# Altium schematic sheet [03] - MAIN SCHEMATIC.SchDoc
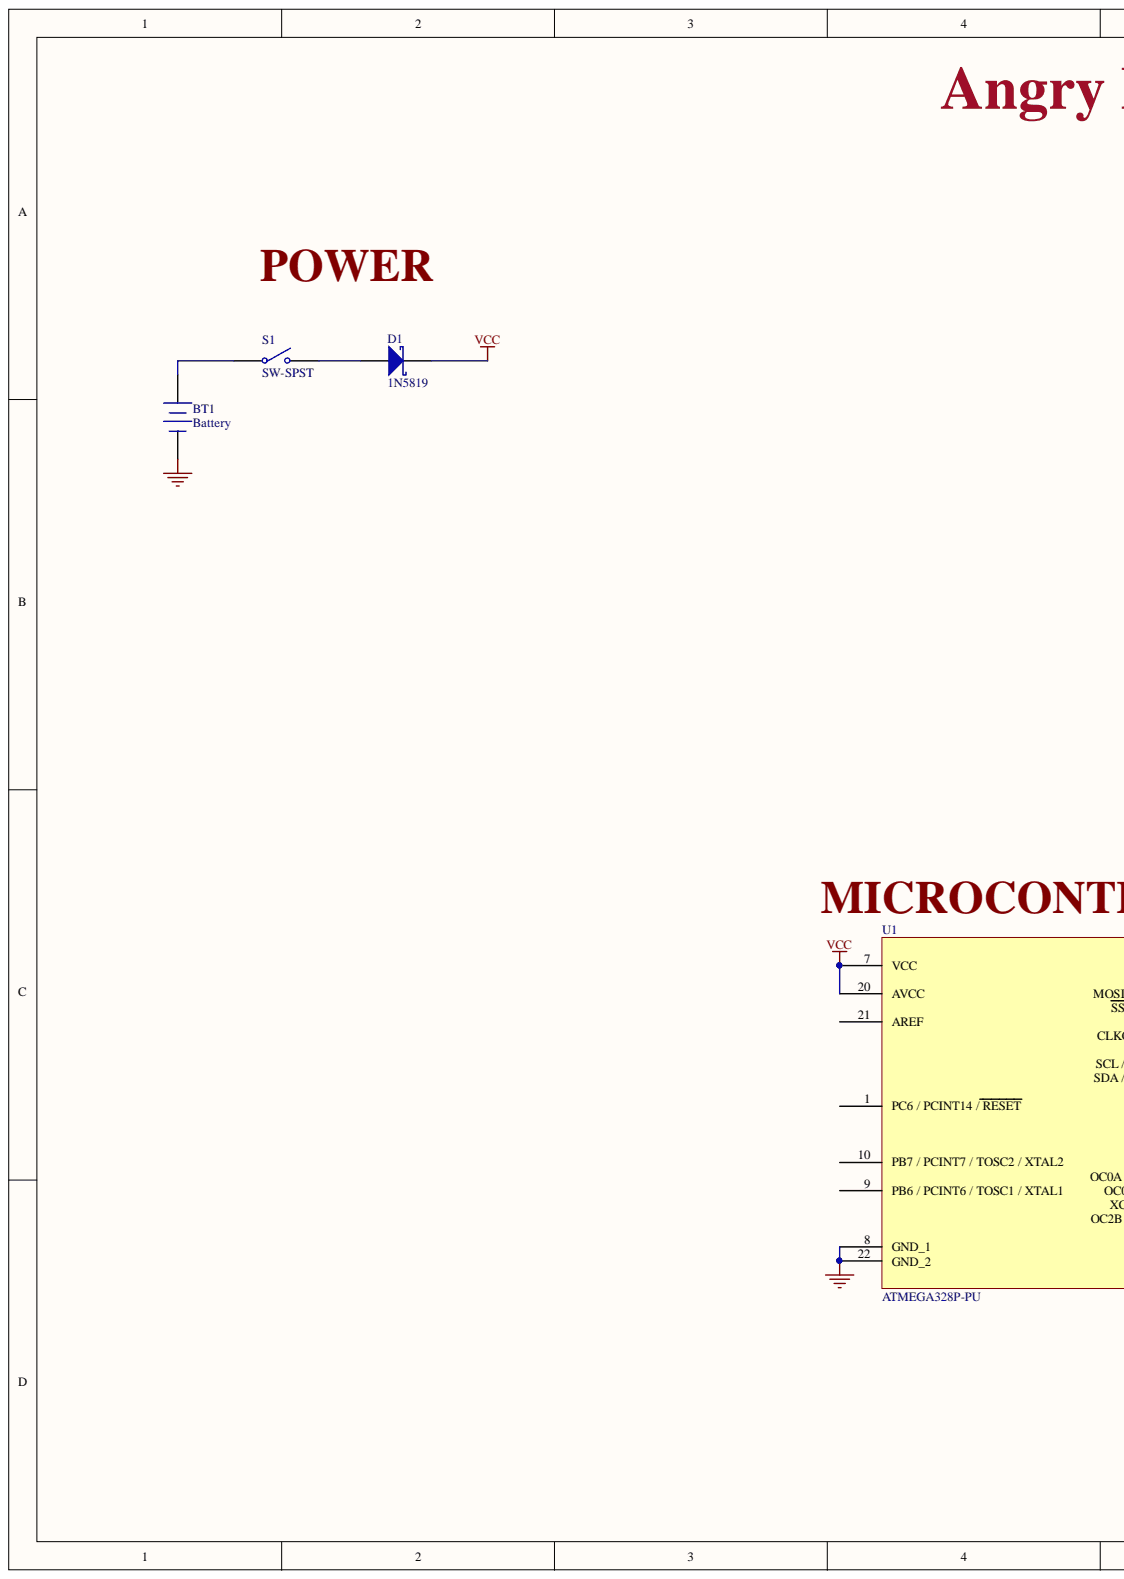
[diagram p0: [03] - MAIN SCHEMATIC.SchDoc, left]
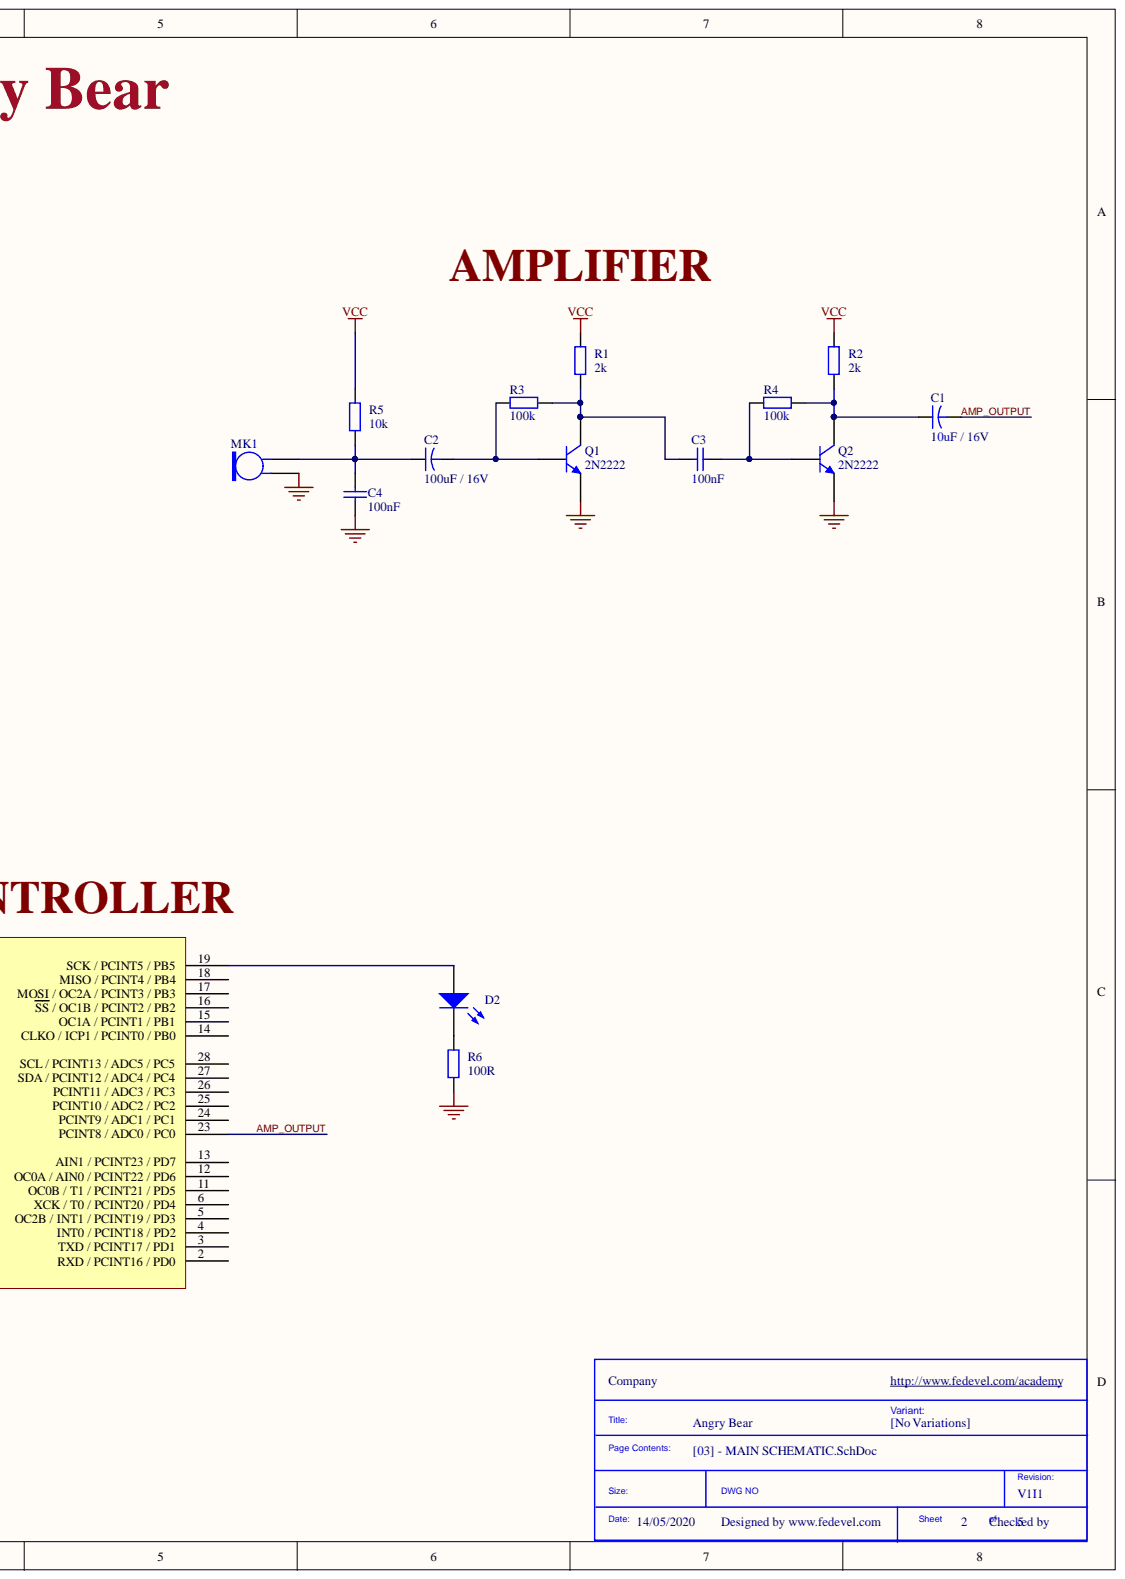
[diagram p1: [03] - MAIN SCHEMATIC.SchDoc, right]

## Parts
BT1  Battery  [BAT-2]
C1  10uF / 16V  [CAPR5-4X5]
C2  100uF / 16V  [CAPR5-4X5]
C3  100nF  [RAD-0.3]
C4  100nF  [RAD-0.3]
D1  1N5819  [DO-204AL]
D2  LED0  [LED-0]  (value not drawn)
MK1  Mic2  [PIN2]  (value not drawn)
Q1  2N2222  [TO-226-AA]
Q2  2N2222  [TO-226-AA]
R1  2k  [AXIAL-0.4]
R2  2k  [AXIAL-0.4]
R3  100k  [AXIAL-0.4]
R4  100k  [AXIAL-0.4]
R5  10k  [AXIAL-0.4]
R6  100R  [AXIAL-0.4]
S1  SW-SPST  [SPST-2]
U1  ATMEGA328P-PU  [28_DIP_7.62mm]

## Nets
GND: BT1.2 C4.1 MK1.1 Q1.3 Q2.3 R6.1 U1.8(GND_1) U1.22(GND_2)
VCC: D1.2 R1.2 R2.2 R5.2 U1.7(VCC) U1.20(AVCC)
AMP_OUTPUT: C1.2 U1.23(PCINT8_/_ADC0_/_PC0)
NetBT1_1: BT1.1 S1.1
NetC1_1: C1.1 Q2.1 R2.1 R4.2
NetC2_1: C2.1 C4.2 MK1.2 R5.1
NetC2_2: C2.2 Q1.2 R3.1
NetC3_1: C3.1 Q1.1 R1.1 R3.2
NetC3_2: C3.2 Q2.2 R4.1
NetD1_1: D1.1 S1.2
NetD2_1: D2.1 U1.19(SCK_/_PCINT5_/_PB5)
NetD2_2: D2.2 R6.2

Not connected: U1 1(PC6_/_PCINT14_/_RESET) 2(RXD_/_PCINT16_/_PD0) 3(TXD_/_PCINT17_/_PD1) 4(INT0_/_PCINT18_/_PD2) 5(OC2B_/_INT1_/_PCINT19_/_PD3) 6(XCK_/_T0_/_PCINT20_/_PD4) 9(PB6_/_PCINT6_/_TOSC1_/_XTAL1) 10(PB7_/_PCINT7_/_TOSC2_/_XTAL2) 11(OC0B_/_T1_/_PCINT21_/_PD5) 12(OC0A_/_AIN0_/_PCINT22_/_PD6) 13(AIN1_/_PCINT23_/_PD7) 14(CLKO_/_ICP1_/_PCINT0_/_PB0) 15(OC1A_/_PCINT1_/_PB1) 16(SS_/_OC1B_/_PCINT2_/_PB2) 17(MOSI_/_OC2A_/_PCINT3_/_PB3) 18(MISO_/_PCINT4_/_PB4) 21(AREF) 24(PCINT9_/_ADC1_/_PC1) 25(PCINT10_/_ADC2_/_PC2) 26(PCINT11_/_ADC3_/_PC3) 27(SDA_/_PCINT12_/_ADC4_/_PC4) 28(SCL_/_PCINT13_/_ADC5_/_PC5)

## Library data of the parts
BT1 = Battery: Multicell Battery; Component Kind: Standard; LatestRevisionDate: 17-Jul-2002; LatestRevisionNote: Re-released for DXP Platform.; Library Name: Miscellaneous Devices.IntLib; PackageDescription: Battery; 2 Leads; PackageReference: BAT-2; Published: 24-Mar-1999; Publisher: Altium Limited
C1 C2 = Cap2: Capacitor; Value: 100pF; Component Kind: Standard; Library Name: Miscellaneous Devices.IntLib; PackageDescription: Capacitor; 2 Leads; PackageReference: CAPR5-4X5; Published: 23-Sep-2002; Signal Integrity: Cap; Simulation: CAP; Publisher: Altium Limited
C3 C4 = Cap: Capacitor; Value: 100pF; Component Kind: Standard; LatestRevisionDate: 17-Jul-2002; LatestRevisionNote: Re-released for DXP Platform.; Library Name: Miscellaneous Devices.IntLib; PackageDescription: Radial Cap, Thru-Hole; 2 Leads; 0.3 in Pin Spacing; PackageReference: RAD-0.3; Published: 8-Jun-2000; Signal Integrity: Cap; Simulation: CAP; Publisher: Altium Limited
D1 = Diode 11DQ03: Schottky Rectifier; Code_JEDEC: DO-204-AL; Component Kind: Standard; ComponentLink1Description: Manufacturer Link; ComponentLink1URL: http://www.irf.com/; ComponentLink2Description: Datasheet; ComponentLink2URL: http://www.irf.com/product-info/datasheets/data/11dq03.pdf; DatasheetVersion: Dec-1997; LatestRevisionDate: 17-Jul-2002; LatestRevisionNote: Re-released for DXP Platform.; Library Name: Miscellaneous Devices.IntLib; PackageDescription: Diode, Axial; Body 5.2 x 2.7 mm (LxDia. max), Lead Dia. 0.86 mm (max); PackageReference: DO-204AL; Published: 8-Jun-2000; Simulation: 11DQ03; Publisher: Altium Limited
D2 = LED0: Typical INFRARED GaAs LED; Component Kind: Standard; LatestRevisionDate: 08-Jul-2005; LatestRevisionNote: Mech Layer 1 removed.; Library Name: Miscellaneous Devices.IntLib; PackageDescription: LED; 2 Leads; PackageReference: LED-0; Published: 8-Jun-2000; Simulation: LED0; Publisher: Altium Limited
MK1 = Mic2: Microphone; Component Kind: Standard; LatestRevisionDate: 17-Jul-2002; LatestRevisionNote: Re-released for DXP Platform.; Library Name: Miscellaneous Devices.IntLib; PackageReference: PIN2; Published: 24-Mar-1999; Publisher: Altium Limited
Q1 Q2 = NPN: NPN Bipolar Transistor; Manufacturer: ON Semiconductor; Manufacturer Part Number: P2N2222AG; Collector Emitter Voltage V(br)ceo: 40V; DC Collector Current: 600mA; DC Current Gain hFE: 300hFE; No. of Pins: 3Pins; Power Dissipation Pd: 625mW; SVHC: No SVHC (17-Dec-2015); Transistor Case Style: TO-92; Transition Frequency ft: 300MHz; Automotive Qualification Standard: -; Code_JEDEC: TO-226-AA; Component Kind: Standard; ComponentLink1Description: https://www.onsemi.com/pub/Collateral/P2N2222A-D.PDF?src-supplier=Digi-Key; ComponentLink1URL: https://www.onsemi.com/pub/Collateral/P2N2222A-D.PDF?src-supplier=Digi-Key; ComponentLink2Description: http://www.mouser.com/ds/2/308/on%20semiconductor_p2n2222a-d-548821.pdf?src-supplier=Mouser; ComponentLink2URL: http://www.mouser.com/ds/2/308/on%20semiconductor_p2n2222a-d-548821.pdf?src-supplier=Mouser; ComponentLink3Description: http://www.onsemi.com/pub/Collateral/P2N2222A-D.PDF?src-supplier=Avnet; ComponentLink3URL: http://www.onsemi.com/pub/Collateral/P2N2222A-D.PDF?src-supplier=Avnet; ComponentLink4Description: http://www.onsemi.com/pub/Collateral/P2N2222A-D.PDF?src-supplier=Avnet+Europe; ComponentLink4URL: http://www.onsemi.com/pub/Collateral/P2N2222A-D.PDF?src-supplier=Avnet+Europe; ComponentLink5Description: http://www.onsemi.com/pub_link/Collateral/P2N2222A-D.PDF?src-supplier=Future+Electronics; ComponentLink5URL: http://www.onsemi.com/pub_link/Collateral/P2N2222A-D.PDF?src-supplier=Future+Electronics; ComponentLink6Description: https://www.verical.com/server-webapp/html/getDatasheet?partID=69103&src-supplier=Verical; ComponentLink6URL: https://www.verical.com/server-webapp/html/getDatasheet?partID=69103&src-supplier=Verical; LatestRevisionDate: 17-Jul-2002; LatestRevisionNote: Re-released for DXP Platform.; Library Name: Miscellaneous Devices.IntLib; MSL: MSL 1 - Unlimited; Operating Temperature Max: 150°C; PackageDescription: TO, Flat Index; 3 In-Line, Axial Leads; Body Dia. 4.8mm; Leads 0.55 x 0.51 mm (max); PackageReference: TO-226-AA; Product Range: -; Published: 8-Jun-2000; Signal Integrity: NPN; Simulation: NPN; Stock 1: 0; Supplier 1: Newark; Supplier Part Number 1: 42K2503; Transistor Polarity: NPN; Publisher: Altium Limited
R1-R6 = Res2: Resistor; Value: 1K; Component Kind: Standard; LatestRevisionDate: 17-Jul-2002; LatestRevisionNote: Re-released for DXP Platform.; Library Name: Miscellaneous Devices.IntLib; PackageDescription: Axial Device, Thru-Hole; 2 Leads; 0.4 in Pin Spacing; PackageReference: AXIAL-0.4; Published: 8-Jun-2000; Signal Integrity: Res; Simulation: RESISTOR; Publisher: Altium Limited
S1 = SW-SPST: Single-Pole, Single-Throw Switch; Component Kind: Standard; LatestRevisionDate: 17-Jul-2002; LatestRevisionNote: Re-released for DXP Platform.; Library Name: Miscellaneous Devices.IntLib; PackageDescription: Switch; 2 Leads; PackageReference: SPST-2; Published: 24-Mar-1999; Publisher: Altium Limited
U1 = ATMEGA328P-PU: IC MCU 8BIT 32KB FLASH 28DIP; Manufacturer: Atmel; .Checked PCB: RF; .Creator: MM; Connectivity: I²C, SPI, UART/USART; Core Processor: AVR; Core Size: 8-Bit; Data Converters: A/D 6x10b; EEPROM Size: 1K x 8; Number of I/O: 23; Oscillator Type: Internal; Peripherals: Brown-out Detect/Reset, POR, PWM, WDT; Program Memory Size: 32KB (16K x 16); RAM Size: 2K x 8; Speed: 20MHz; Voltage - Supply (Vcc/Vdd): 1.8 V ~ 5.5 V; Category: Integrated Circuits (ICs); Component Kind: Standard; ComponentLink1Description: http://www.atmel.com/images/atmel-8271-8-bit-avr-microcontroller-atmega48a-48pa-88a-88pa-168a-168pa-328-328p_datasheet_summary.pdf; ComponentLink1URL: http://www.atmel.com/images/atmel-8271-8-bit-avr-microcontroller-atmega48a-48pa-88a-88pa-168a-168pa-328-328p_datasheet_summary.pdf; Library Name: 28Pins_Project_V1I1.SCHLIB; Manufacturer Part Number: ATMEGA328P-PU; Operating Temperature: -40°C ~ 85°C; Package / Case: 28-DIP (0.300", 7.62mm); Packaging: Tube; Pricing 1: 1=3.7, 10=3.305, 100=2.7103, 250=2.44588, 500=2.19468, 1000=1.85094 (USD); Program Memory Type: FLASH; RoHS: RoHS Compliant; Stock 1: 47234; Supplier 1: Digi-Key; Supplier Device Package: 28-PDIP; Supplier Part Number 1: ATMEGA328P-PU-ND; .Checked SCH: RF
